# Altium schematic sheet [03] - BTNs, SWs.SchDoc("[03] - BTNs, SWs")
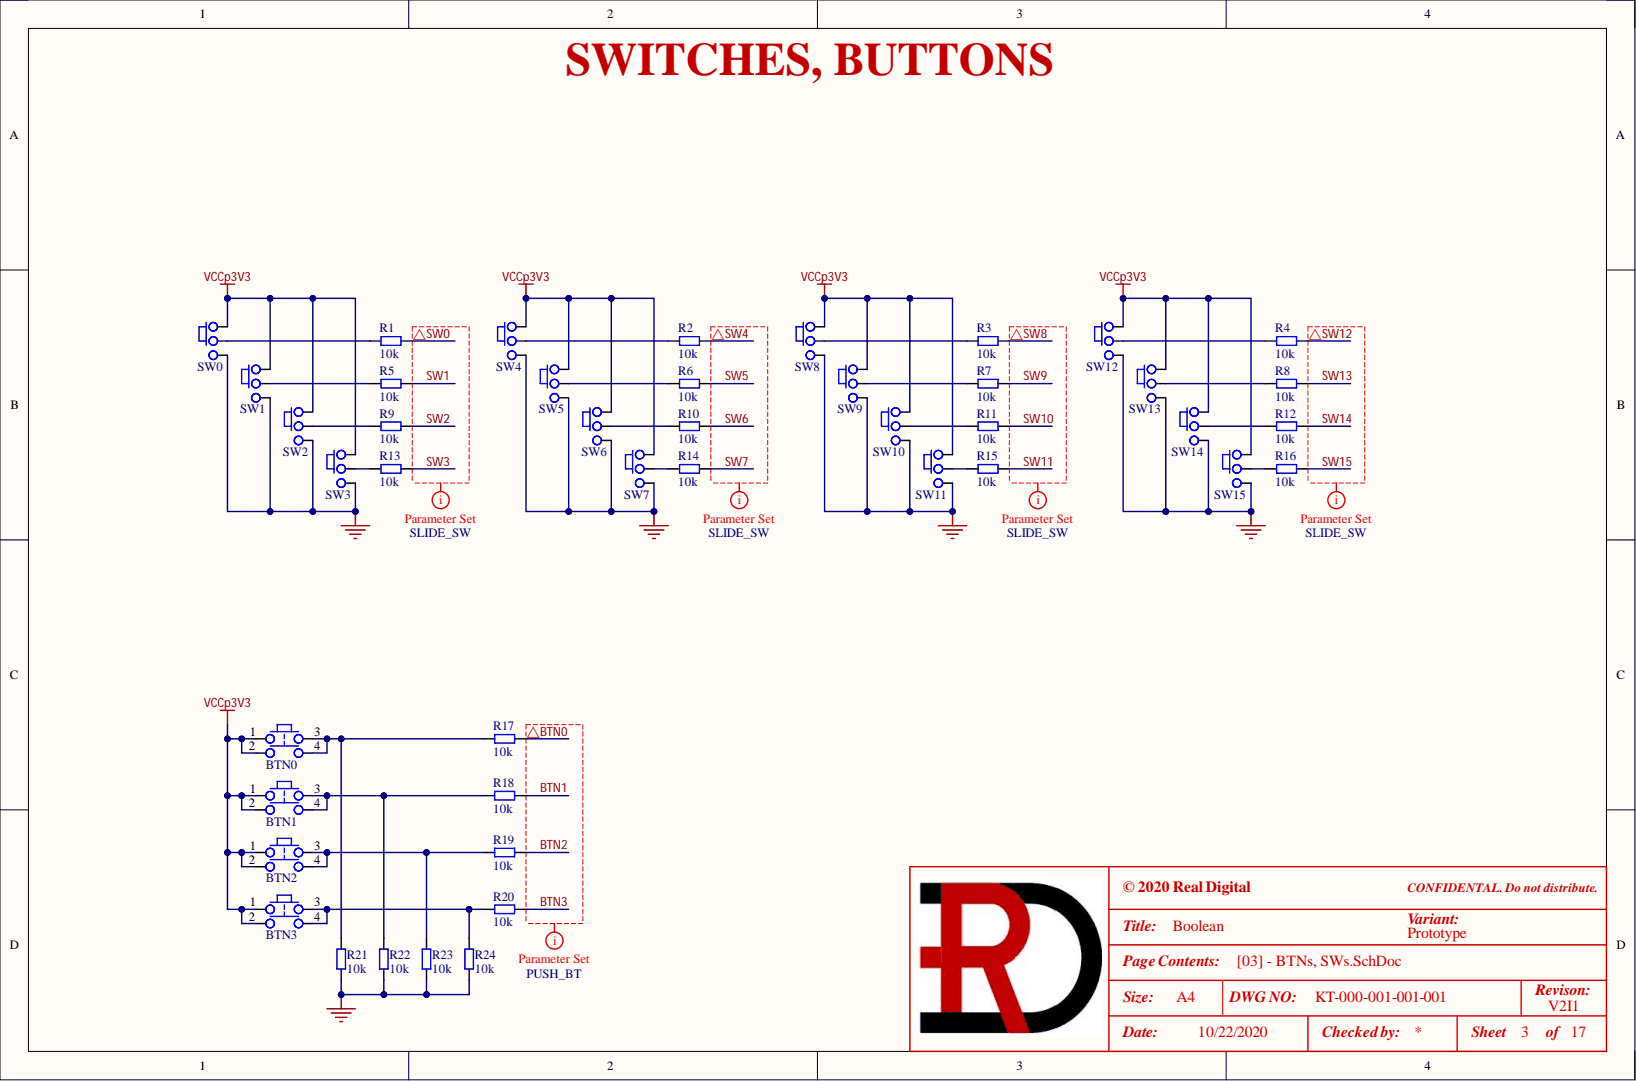
[diagram p0: [03] - BTNs, SWs.SchDoc]

## Parts
BTN0  PTA-142  [PTA-142]  (value not drawn)
BTN1  PTA-142  [PTA-142]  (value not drawn)
BTN2  PTA-142  [PTA-142]  (value not drawn)
BTN3  PTA-142  [PTA-142]  (value not drawn)
R1  10k  [R0402]
R2  10k  [R0402]
R3  10k  [R0402]
R4  10k  [R0402]
R5  10k  [R0402]
R6  10k  [R0402]
R7  10k  [R0402]
R8  10k  [R0402]
R9  10k  [R0402]
R10  10k  [R0402]
R11  10k  [R0402]
R12  10k  [R0402]
R13  10k  [R0402]
R14  10k  [R0402]
R15  10k  [R0402]
R16  10k  [R0402]
R17  10k  [R0402]
R18  10k  [R0402]
R19  10k  [R0402]
R20  10k  [R0402]
R21  10k  [R0402]
R22  10k  [R0402]
R23  10k  [R0402]
R24  10k  [R0402]
SW0  Switch  [SW-10SP001]  (value not drawn)
SW1  Switch  [SW-10SP001]  (value not drawn)
SW2  Switch  [SW-10SP001]  (value not drawn)
SW3  Switch  [SW-10SP001]  (value not drawn)
SW4  Switch  [SW-10SP001]  (value not drawn)
SW5  Switch  [SW-10SP001]  (value not drawn)
SW6  Switch  [SW-10SP001]  (value not drawn)
SW7  Switch  [SW-10SP001]  (value not drawn)
SW8  Switch  [SW-10SP001]  (value not drawn)
SW9  Switch  [SW-10SP001]  (value not drawn)
SW10  Switch  [SW-10SP001]  (value not drawn)
SW11  Switch  [SW-10SP001]  (value not drawn)
SW12  Switch  [SW-10SP001]  (value not drawn)
SW13  Switch  [SW-10SP001]  (value not drawn)
SW14  Switch  [SW-10SP001]  (value not drawn)
SW15  Switch  [SW-10SP001]  (value not drawn)

## Nets
GND: R21.1 R22.1 R23.1 R24.1 SW0.3 SW1.3 SW2.3 SW3.3 SW4.3 SW5.3 SW6.3 SW7.3 SW8.3 SW9.3 SW10.3 SW11.3 SW12.3 SW13.3 SW14.3 SW15.3
VCCp3V3: BTN0.1 BTN0.2 BTN1.1 BTN1.2 BTN2.1 BTN2.2 BTN3.1 BTN3.2 SW0.1 SW1.1 SW2.1 SW3.1 SW4.1 SW5.1 SW6.1 SW7.1 SW8.1 SW9.1 SW10.1 SW11.1 SW12.1 SW13.1 SW14.1 SW15.1
BTN0: R17.2
BTN1: R18.2
BTN2: R19.2
BTN3: R20.2
SW0: R1.2
SW1: R5.2
SW2: R9.2
SW3: R13.2
SW4: R2.2
SW5: R6.2
SW6: R10.2
SW7: R14.2
SW8: R3.2
SW9: R7.2
SW10: R11.2
SW11: R15.2
SW12: R4.2
SW13: R8.2
SW14: R12.2
SW15: R16.2
NetBTN0_3: BTN0.3 BTN0.4 R17.1 R21.2
NetBTN1_3: BTN1.3 BTN1.4 R18.1 R22.2
NetBTN2_3: BTN2.3 BTN2.4 R19.1 R23.2
NetBTN3_3: BTN3.3 BTN3.4 R20.1 R24.2
NetR1_1: R1.1 SW0.2
NetR2_1: R2.1 SW4.2
NetR3_1: R3.1 SW8.2
NetR4_1: R4.1 SW12.2
NetR5_1: R5.1 SW1.2
NetR6_1: R6.1 SW5.2
NetR7_1: R7.1 SW9.2
NetR8_1: R8.1 SW13.2
NetR9_1: R9.1 SW2.2
NetR10_1: R10.1 SW6.2
NetR11_1: R11.1 SW10.2
NetR12_1: R12.1 SW14.2
NetR13_1: R13.1 SW3.2
NetR14_1: R14.1 SW7.2
NetR15_1: R15.1 SW11.2
NetR16_1: R16.1 SW15.2

## Library data of the parts
BTN0-BTN3 = Tact Switch: SWITCH TACTILE SPST-NO, 4.50mm x 4.50mm; Manufacturer 1: Pacer Technology Co., Ltd; Manufacturer 2 Part Number: TSXH-2GL; .Creator: RV; C P/N: 153-0059; LoadStatus: Load; Category: Tactile Switches; Component Kind: Standard; Library Name: KT-RealDigital.IntLib; Manufacturer 1 Part Number: PTA-142
R1-R24 = RC0402JR-0710KL: RES SMD 10K OHM 5% 1/16W 0402; Manufacturer 1: Yageo; .Checked_PCB: AC; .Creator: RV; C P/N: 101-0047; LoadStatus: Load; Category: Resistors; Component Kind: Standard; Library Name: KT-RealDigital.IntLib; Manufacturer 1 Part Number: RC0402JR-0710KL; Tolerance: 5%; .Checked_SCH: AC
SW0-SW15 = Slide_Switch: Slide Switch, SPDT, Thru Hole,; Manufacturer 1: Mountain Switch; Manufacturer 1 Part Number: 10SP001; Manufacturer 2 Part Number: BSL-SS12F56-V4; .Checked_PCB: AC; .Creator: RV; C P/N: 153-0001; LoadStatus: Load; Category: Switches; Component Kind: Standard; Library Name: KT-RealDigital.IntLib; .Checked_SCH: AC
